# Altium schematic sheet Sensors.SchDoc(Sensors)
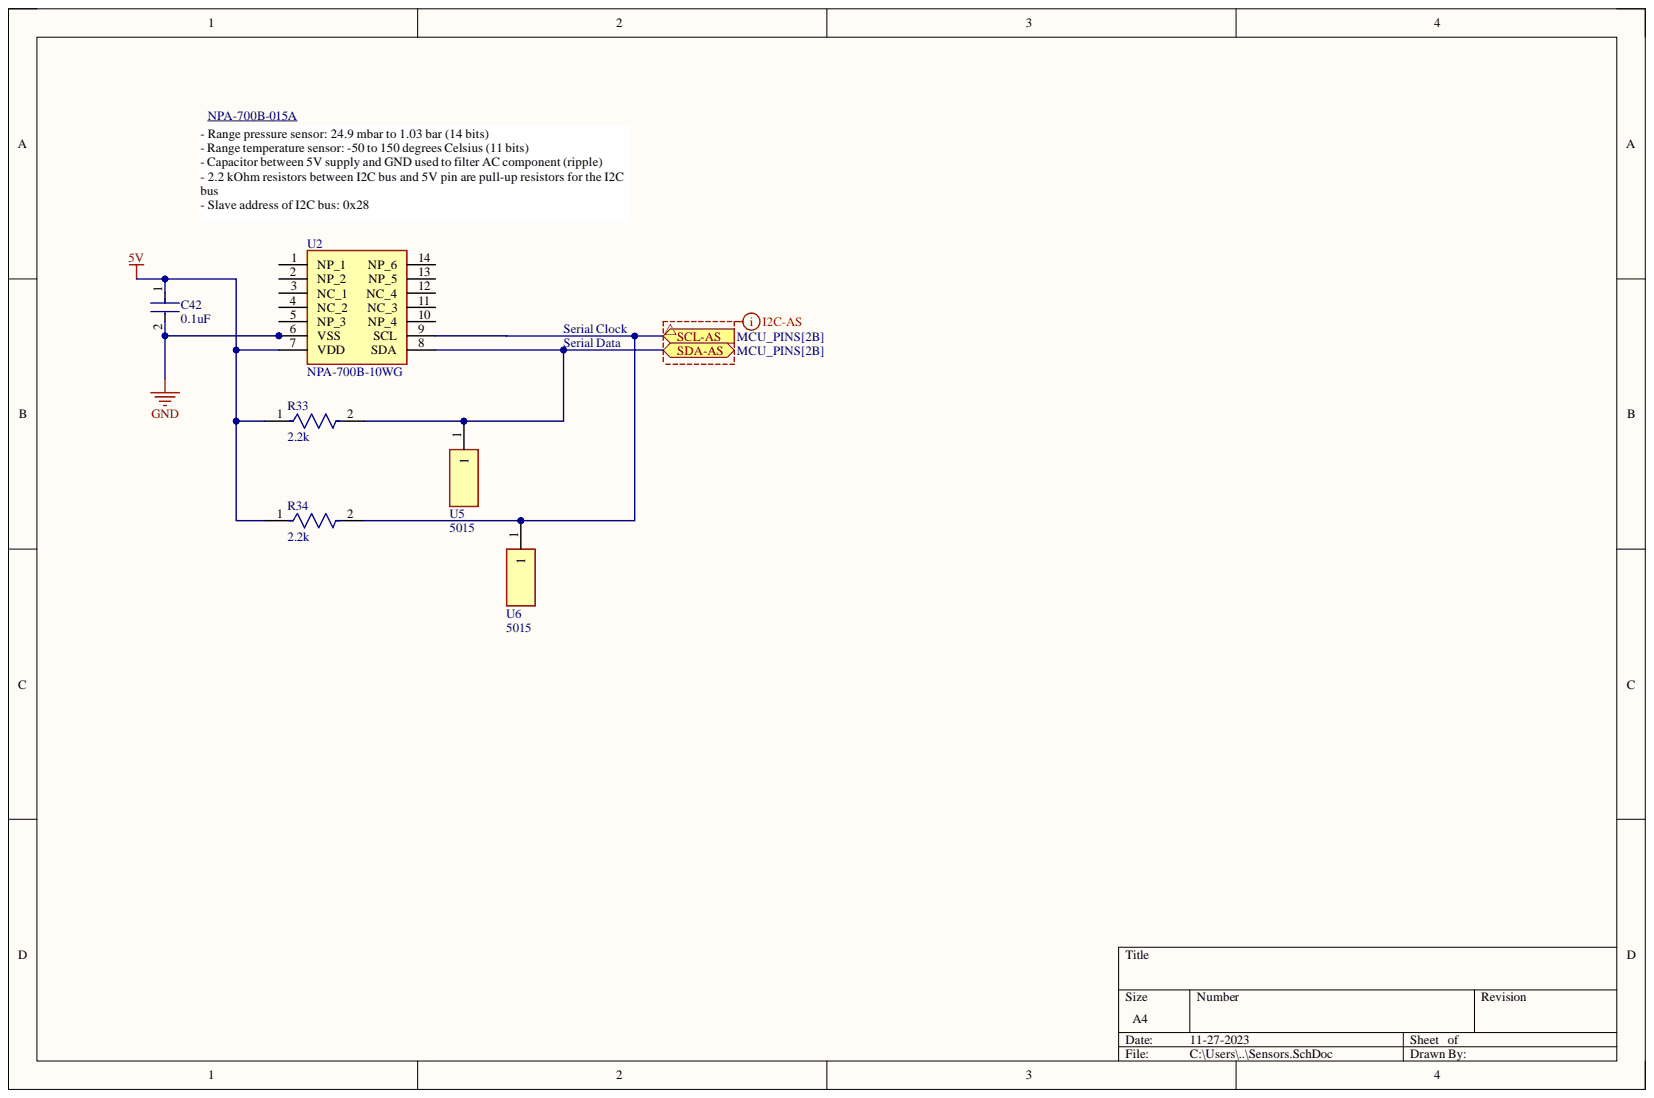
[diagram p0: Sensors.SchDoc]

## Parts
C42  0.1uF  [FP-C0603C-CF-MFG]
R33  2.2k  [FP-RT0603-MFG]
R34  2.2k  [FP-RT0603-MFG]
U2  NPA-700B-10WG  [NPA700B10WG]
U5  5015  [5015]
U6  5015  [5015]

## Ports
SCL-AS, SDA-AS

## Nets
5V: C42.1 R33.1 R34.1 U2.7(VDD)
GND: C42.2 U2.6(VSS)
SCL-AS: R34.2 U2.9(SCL) U6.1 ; port SCL-AS
SDA-AS: R33.2 U2.8(SDA) U5.1 ; port SDA-AS

Not connected: U2 1(NP_1) 2(NP_2) 3(NC_1) 4(NC_2) 5(NP_3) 10(NP_4) 11(NC_3) 12(NC_4) 13(NP_5) 14(NP_6)

## Library data of the parts
C42 = CMP-03020-019612-2: C0603 0.10 μF X7R 30ppm/°C 20.00% 16 V; Manufacturer: KEMET; Manufacturer Part Number: C0603C104M4RAC; Automotive Grade: Grade 1; Capacitance: 0.10 μF; Material: Ceramic; Tolerance: 0.2; Value: 0.10 μF; Voltage Rating: 16 V; Automotive: Yes; Category: Cap; Component Kind: Standard; ComponentLink2Description: Datasheet; ComponentLink2URL: https://api.kemet.com/component-edge/download/datasheet/C0603C100J5GACAUTO.pdf; Device Class L1: Passive Components; Device Class L2: Capacitors; Device Class L3: Ceramic Capacitors; Height: 0.87mm; Lead Free: Yes; Library Name: Altium Content Vault; Mouser Description: Ceramic Multilayer Capacitor; Capacitor Type: General Purpose; Capacitance: 0.1uF; Capacitance Tolerance: +/- 20%;...; Octopart: https://octopart.com/c0603c104m4rac-kemet-1325405; Package: C0603C-CF; RoHS: TRUE; Temperature: -55°C to +125°C; Temperature Characteristic: X7R; Temperature Coefficient: 30ppm/°C; Temperature Range High: +125°C; Temperature Range Low: -55°C
R33 R34 = CMP-03412-033768-1: RES SMD 2.2K OHM 1% 1/10W 0603; Manufacturer: Yageo; Manufacturer Part Number: RT0603FRE072K2L; Power Rating: 0.1W; Resistance: 2.2KΩ; Temperature Coefficient: 50 ppm/°C; Tolerance: 0.01; Value: 2.2KΩ; Voltage Rating: 75V; Automotive: No; Category: Res; Component Kind: Standard; ComponentLink2Description: Datasheet; ComponentLink2URL: http://www.yageo.com/documents/recent/PYu-RT_1-to-0.01_RoHS_L_9.pdf; Composition: Thin Film; Device Class L1: Passive Components; Device Class L2: Resistors; Device Class L3: Chip SMD Resistors; Digikey Description: RES SMD 2.2K OHM 1% 1/10W 0603; Footprint Url: http://www.yageo.com/exep/pages/download/literatures/PYu-R_Mount_10.pdf; Height: 0.55mm; Ipc Land Pattern Name: RESC16080X45; Lead Free: Yes; Library Name: Altium Content Vault; Mouser Description: High Precision-High Stability Chip Resistor Thin Film 0603 2.2kOhm 1% Paper T/R; Octopart: https://octopart.com/rt0603fre072k2l-yageo-40019811; Package: RT0603; RoHS: TRUE; Series: RT; Temperature: -55°C to +155°C; Temperature Range High: +155°C; Temperature Range Low: -55°C
U2 = NPA-700B-10WG: Undefined or Miscellaneous; Manufacturer_Name: Amphenol; Arrow Part Number: NPA-700B-10WG; Arrow Price/Stock: https://www.arrow.com/en/products/npa-700b-10wg/amphenol; Component Kind: Standard; Datasheet Link: https://datasheet.datasheetarchive.com/originals/distributors/DKDS-11/209188.pdf; Height: 9.5mm; Manufacturer_Part_Number: NPA-700B-10WG; Mouser Part Number: 527-NPA-700B-010WG; Mouser Price/Stock: https://www.mouser.co.uk/ProductDetail/Amphenol-Advanced-Sensors/NPA-700B-10WG?qs=lQkyTFOf3aJgxzyHiMD5gQ%3D%3D
U5 U6 = 5015: Undefined or Miscellaneous; Manufacturer_Name: Keystone Electronics; Arrow Part Number: 5015; Arrow Price/Stock: https://www.arrow.com/en/products/5015/keystone-electronics?region=europe; Component Kind: Standard; Datasheet Link: http://www.keyelco.com/product-pdf.cfm?p=1353; Height: 1.52mm; Manufacturer_Part_Number: 5015; Mouser Part Number: 534-5015; Mouser Price/Stock: https://www.mouser.co.uk/ProductDetail/Keystone-Electronics/5015?qs=qOqV1E1P08ShW56PtUq2FA%3D%3D
